# Altium schematic sheet AES-MS-MT3620-SK-G_Dev.SchDoc(AES-MS-MT3620-SK-G_Dev)
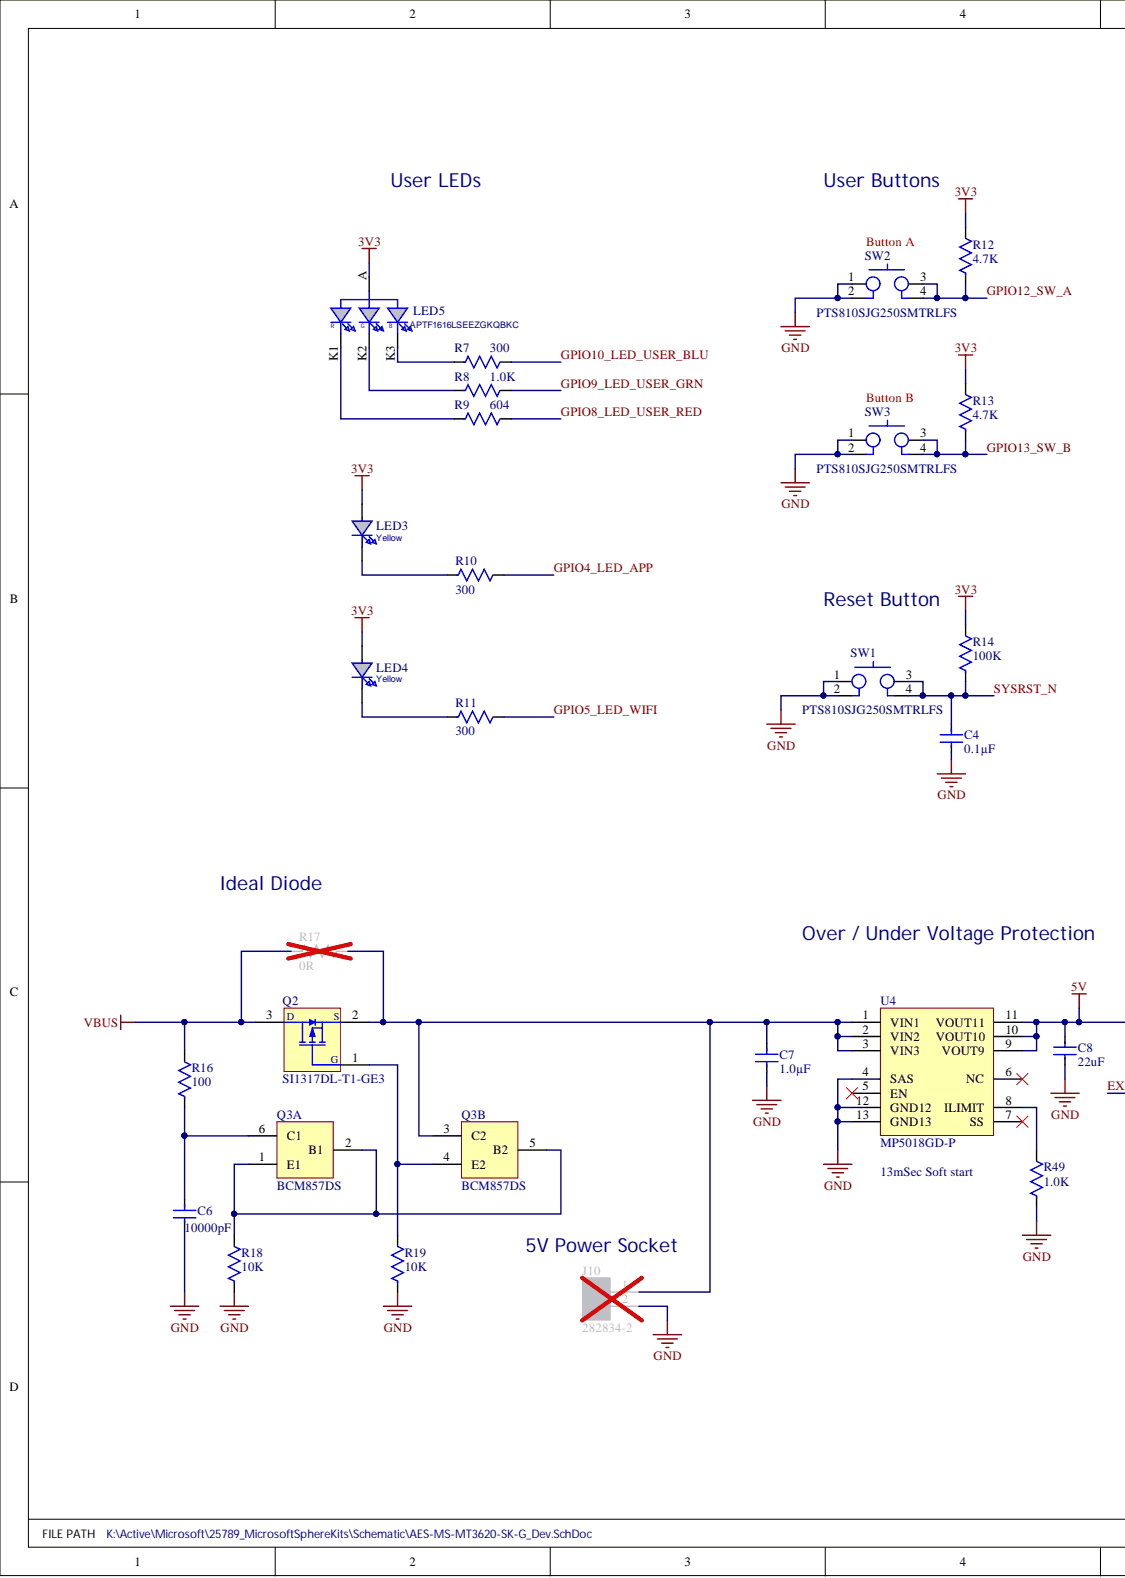
[diagram p0: AES-MS-MT3620-SK-G_Dev.SchDoc, left]
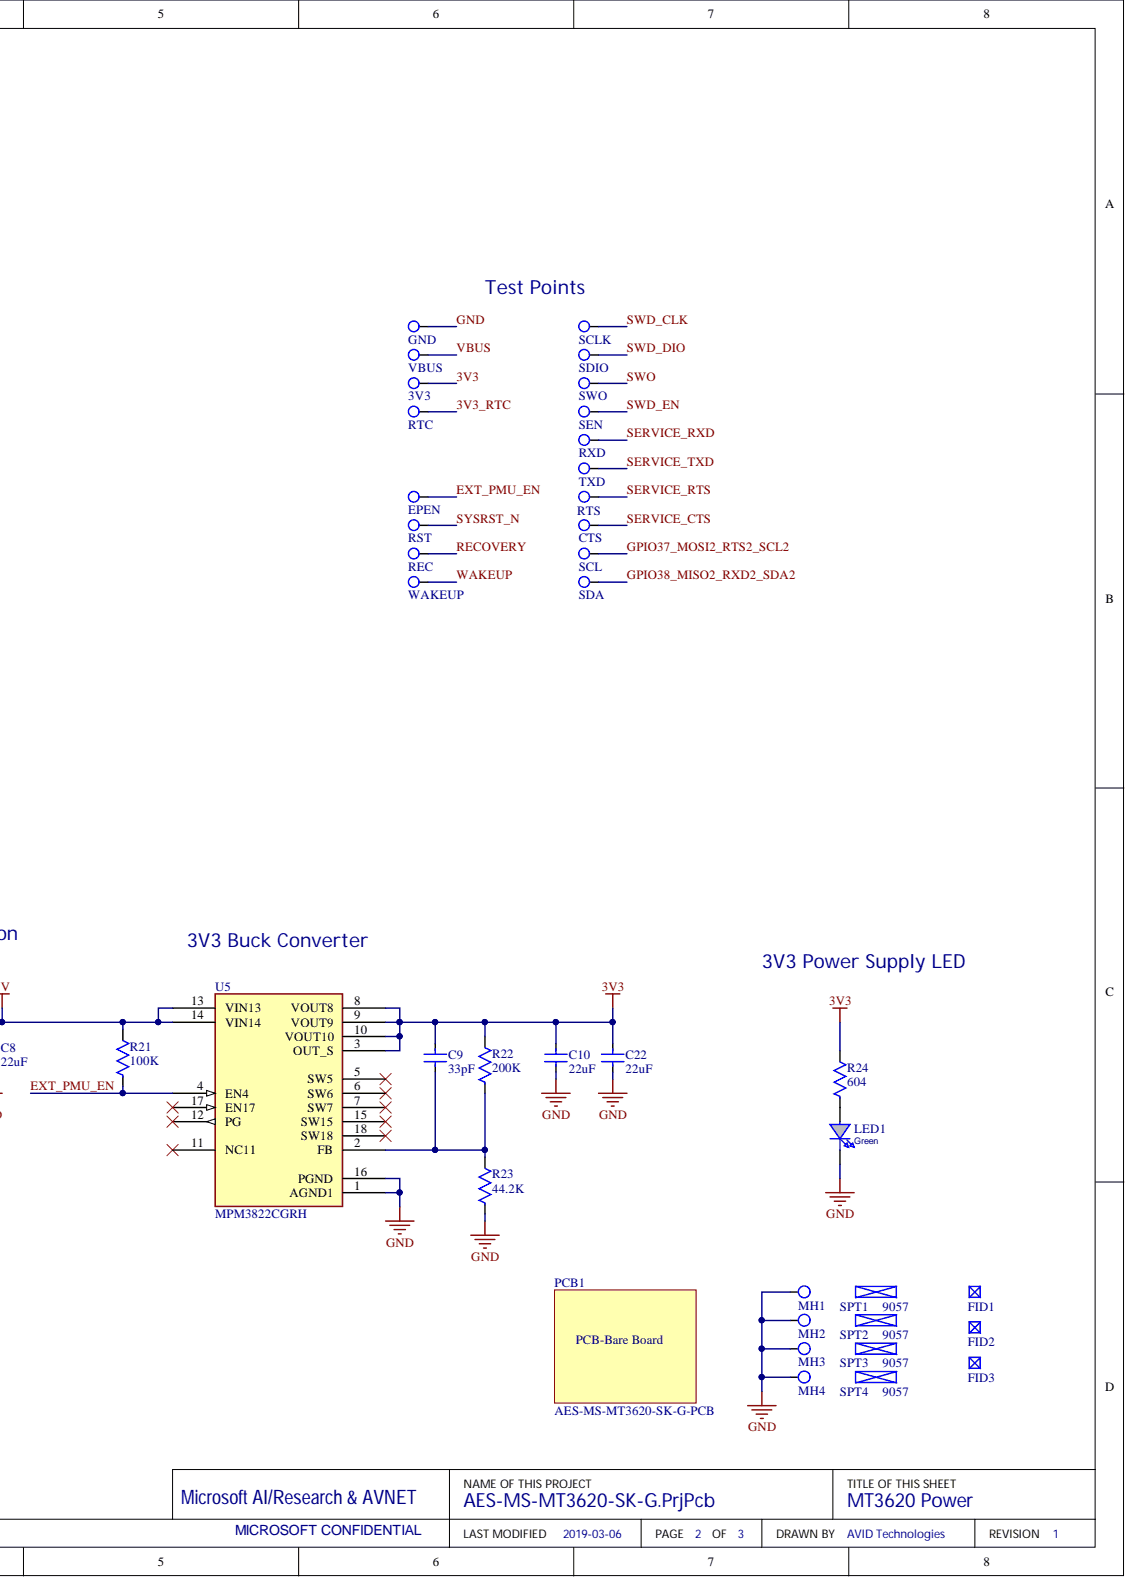
[diagram p1: AES-MS-MT3620-SK-G_Dev.SchDoc, right]

## Parts
3V3  TestPoint  [Pad1.27mm]  (no BOM)
C4  Capacitance: 0.1µF  [CAPC1005-EIA0402 0.55MM MED]  (hidden comment: 0.1uF)
C6  10000pF  [CAPC1005-EIA0402 0.55MM MED]
C7  1.0µF  [CAPC1005-EIA0402 0.55MM MED]
C8  22uF  [TDK_0805]
C9  33pF  [CAPC1005-EIA0402 0.55MM MED]
C10  22uF  [TDK_0805]
C22  22uF  [TDK_0805]
CTS  TestPoint  [Pad1.27mm]  (no BOM)
EPEN  TestPoint  [Pad1.27mm]  (no BOM)
GND  TestPoint  [Pad1.27mm]  (no BOM)
J10  282834-2  [282834-2-TB]
LED1  Green  [LG_L29K-F2J1-24-Z]
LED3  Yellow
LED4  Yellow
LED5  APTF1616LSEEZGKQBKC  [APTF1616]
MH1  Mounting Hole  [MH]  (value not drawn)  (no BOM)
MH2  Mounting Hole  [MH]  (value not drawn)  (no BOM)
MH3  Mounting Hole  [MH]  (value not drawn)  (no BOM)
MH4  Mounting Hole  [MH]  (value not drawn)  (no BOM)
PCB1  AES-MS-MT3620-SK-G-PCB
Q2  SI1317DL-T1-GE3  [VISHAY_SOT-323]
Q3  BCM857DS  [NXP-SOT457]  (parts A,B)
R7  300  [RES 0402 MEDIUM DENSITY]
R8  1.0K  [RES 0402 MEDIUM DENSITY]
R9  604  [RES 0402 MEDIUM DENSITY]
R10  300  [RES 0402 MEDIUM DENSITY]
R11  300  [RES 0402 MEDIUM DENSITY]
R12  4.7K  [RES 0402 MEDIUM DENSITY]
R13  4.7K  [RES 0402 MEDIUM DENSITY]
R14  100K  [RES 0402 MEDIUM DENSITY]
R16  100  [RES 0402 MEDIUM DENSITY]
R17  Resistance (Ohms): 0R  [RES 0402 MEDIUM DENSITY]  (hidden comment: 0.0)
R18  10K  [RES 0402 MEDIUM DENSITY]
R19  10K  [RES 0402 MEDIUM DENSITY]
R21  100K  [RES 0402 MEDIUM DENSITY]
R22  200K  [RES 0402 MEDIUM DENSITY]
R23  44.2K  [RES 0402 MEDIUM DENSITY]
R24  604  [RES 0402 MEDIUM DENSITY]
R49  1.0K  [RES 0402 MEDIUM DENSITY]
REC  TestPoint  [Pad1.27mm]  (no BOM)
RST  TestPoint  [Pad1.27mm]  (no BOM)
RTC  TestPoint  [Pad1.27mm]  (no BOM)
RTS  TestPoint  [Pad1.27mm]  (no BOM)
RXD  TestPoint  [Pad1.27mm]  (no BOM)
SCL  TestPoint  [Pad1.27mm]  (no BOM)
SCLK  TestPoint  [Pad1.27mm]  (no BOM)
SDA  TestPoint  [Pad1.27mm]  (no BOM)
SDIO  TestPoint  [Pad1.27mm]  (no BOM)
SEN  TestPoint  [Pad1.27mm]  (no BOM)
SPT1  Manufacturer Part Number 1: 9057  (hidden comment: SUPPORT 1/4IN)  (mechanical)
SPT2  Manufacturer Part Number 1: 9057  (hidden comment: SUPPORT 1/4IN)  (mechanical)
SPT3  Manufacturer Part Number 1: 9057  (hidden comment: SUPPORT 1/4IN)  (mechanical)
SPT4  Manufacturer Part Number 1: 9057  (hidden comment: SUPPORT 1/4IN)  (mechanical)
SW1  PTS810SJG250SMTRLFS  [PTS810]
SW2  PTS810SJG250SMTRLFS  [PTS810]
SW3  PTS810SJG250SMTRLFS  [PTS810]
SWO  TestPoint  [Pad1.27mm]  (no BOM)
TXD  TestPoint  [Pad1.27mm]  (no BOM)
U4  MP5018GD-P  [MP5018GD_QFN-12]
U5  MPM3822CGRH  [MPM3822C_QFN-18]
VBUS  TestPoint  [Pad1.27mm]  (no BOM)
WAKEUP  TestPoint  [Pad1.27mm]  (no BOM)

## Nets
5V: C8.2 R21.1 U4.9(VOUT9) U4.10(VOUT10) U4.11(VOUT11) U5.13(VIN13) U5.14(VIN14)
3V3: 3V3.1 C9.2 C10.2 C22.2 LED3.A LED4.A LED5.A R12.1 R13.1 R14.1 R22.1 R24.1 U5.3(OUT_S) U5.8(VOUT8) U5.9(VOUT9) U5.10(VOUT10)
3V3_RTC: RTC.1
EXT_PMU_EN: EPEN.1 R21.2 U5.4(EN4)
GND: C4.1 C6.1 C7.1 C8.1 C10.1 C22.1 GND.1 J10.2 LED1.K MH1.1 MH2.1 MH3.1 MH4.1 R18.2 R19.2 R23.2 R49.2 SW1.1 SW1.2 SW2.1 SW2.2 SW3.1 SW3.2 U4.4(SAS) U4.12(GND12) U4.13(GND13) U5.1(AGND1) U5.16(PGND)
GPIO4_LED_APP: R10.1
GPIO5_LED_WIFI: R11.1
GPIO8_LED_USER_RED: R9.1
GPIO9_LED_USER_GRN: R8.1
GPIO10_LED_USER_BLU: R7.1
GPIO12_SW_A: R12.2 SW2.3 SW2.4
GPIO13_SW_B: R13.2 SW3.3 SW3.4
GPIO37_MOSI2_RTS2_SCL2: SCL.1
GPIO38_MISO2_RXD2_SDA2: SDA.1
RECOVERY: REC.1
SERVICE_CTS: CTS.1
SERVICE_RTS: RTS.1
SERVICE_RXD: RXD.1
SERVICE_TXD: TXD.1
SWD_CLK: SCLK.1
SWD_DIO: SDIO.1
SWD_EN: SEN.1
SWO: SWO.1
SYSRST_N: C4.2 R14.2 RST.1 SW1.3 SW1.4
VBUS: Q2.3 R16.1 R17.2 VBUS.1
WAKEUP: WAKEUP.1
NetC6_2: C6.2 Q3.6(C1) R16.2
NetC7_2: C7.2 J10.1 Q2.2 Q3.3(C2) R17.1 U4.1(VIN1) U4.2(VIN2) U4.3(VIN3)
NetC9_1: C9.1 R22.2 R23.1 U5.2(FB)
NetLED1_A: LED1.A R24.2
NetLED3_K: LED3.K R10.2
NetLED4_K: LED4.K R11.2
NetLED5_K1: LED5.K1 R9.2
NetLED5_K2: LED5.K2 R8.2
NetLED5_K3: LED5.K3 R7.2
NetQ2_1: Q2.1 Q3.4(E2) R19.1
NetQ3_1: Q3.1(E1) Q3.2(B1) Q3.5(B2) R18.1
NetR49_1: R49.1 U4.8(ILIMIT)

Not connected: U4 5(EN) 6(NC) 7(SS); U5 5(SW5) 6(SW6) 7(SW7) 11(NC11) 12(PG) 15(SW15) 17(EN17) 18(SW18)

## Library data of the parts
3V3 CTS EPEN GND REC RST RTC RTS RXD SCL SCLK SDA SDIO SEN SWO TXD VBUS WAKEUP = TestPoint: Test Point; Library Name: generic.SchLib
C4 = 0.1µF 0402 X7R 50V: CAP CER 0.1UF 25V 10% X5R 0402; Manufacturer Part Number 1: CL05A104KA5NNNC; Manufacturer 1: Samsung; Width: 0.02inch; Voltage Rating (DC): 25V; Voltage Rating: 25V; Voltage: 25V; Tolerance: 10%; Thickness: 0.022inch; Supplier Part Number 1: 1276-1043-1-ND; Supplier 1: Digi-Key; Stock 1: 100000; Radiation Hardening: No; Pricing 1: 1=0.1, 10=0.032, 100=0.0141, 500=0.0101, 1000=0.0079, 2500=0.0072, 5000=0.0066 (USD); Packaging: Tape and Reel; Package Quantity: 1; Mount: Surface Mount; Min Operating Temperature: -55°C; Max Operating Temperature: 85°C; Length: 1mm; Lead Free: Lead Free; Height: 0.5mm; Dielectric: X5R; Depth: 0.5mm; Component Kind: Standard; Case Code (Metric): 1005; Case Code (Imperial): 0402; Case/Package: 0402; Library Name: Sphere.SCHLIB
C6 = 10000pF 0402 X7R 50V: CAP CER 10000PF 50V 10% X7R 0402; Manufacturer Part Number 1: C1005X7R1H103K050BB; Manufacturer 1: TDK; Voltage - Rated: 50V; Tolerance: ±10%; Thickness (Max): 0.022" (0.55mm); Temperature Coefficient: X7R; Supplier Part Number 1: 445-6850-2-ND; Supplier 1: Digi-Key; Stock 1: 47833; Size / Dimension: 0.039" L x 0.020" W (1.00mm x 0.50mm); RoHS: RoHS Compliant; Ratings: -; Pricing 1: 0.00685; Packaging: Tape & Reel (TR); Package / Case: 0402 (1005 Metric); Operating Temperature: -55°C ~ 125°C; Mounting Type: Surface Mount, MLCC; Lead Style: -; Lead Spacing: -; Height - Seated (Max): -; Features: -; Component Kind: Standard; Category: Capacitors; Capacitance: 10000pF; Applications: General Purpose; Library Name: Sphere.SCHLIB
C7 = 1.0µF 0402 X5R 35V: CAP CER 1UF 35V 10% X5R 0402; Manufacturer Part Number 1: C1005X5R1V105K050BC; Manufacturer 1: TDK Corporation; Voltage - Rated: 35V; Tolerance: ±10%; Thickness (Max): 0.022" (0.55mm); Temperature Coefficient: X5R; Supplier Part Number 1: 445-9073-2-ND; Supplier 1: Digi-Key; Stock 1: 47833; Size / Dimension: 0.039" L x 0.020" W (1.00mm x 0.50mm); RoHS: RoHS Compliant; Ratings: -; Pricing 1: 0.0186; Packaging: Tape & Reel (TR); Package / Case: 0402 (1005 Metric); Operating Temperature: -55°C ~ 125°C; Mounting Type: Surface Mount, MLCC; Lead Style: -; Lead Spacing: -; Height - Seated (Max): -; Features: -; Component Kind: Standard; Category: Capacitors; Capacitance: 1.0µF; Applications: General Purpose; Library Name: Sphere.SCHLIB
C8 C10 C22 = 22uF 0805 X5R 16V: CAP CER 22UF 16V X5R 0805; Manufacturer Part Number 1: CL21A226MOCLRNC; Manufacturer 1: Samsung; Tolerance: 20%; Width: 0.049inch; Voltage Rating: 16V; Thickness: 0.037inch; Supplier Part Number 1: 1276-6780-1-ND; Supplier 1: Digi-Key; Stock 1: 40000; Pricing 1: 1=0.36, 10=0.246, 100=0.1397, 500=0.0986, 1000=0.0863 (USD); Packaging: Tape and Reel; Mount: Surface Mount; Min Operating Temperature: -55°C; Max Operating Temperature: 85°C; Length: 0.079inch; Component Kind: Standard; Case Code (Metric): 2012; Case Code (Imperial): 0805; Case/Package: 0805; Capacitance: 22uF; Library Name: Sphere.SCHLIB
C9 = 33pF 0402 C0G, NP0 50V: CAP CER 33PF 50V 5% NP0 0402; Manufacturer Part Number 1: C1005C0G1H330J050BA; Manufacturer 1: TDK; Voltage - Rated: 50V; Tolerance: ±5%; Thickness (Max): 0.022" (0.55mm); Temperature Coefficient: C0G, NP0; Supplier Part Number 1: 445-1241-2-ND; Supplier 1: Digi-Key; Stock 1: 47833; Size / Dimension: 0.039" L x 0.020" W (1.00mm x 0.50mm); RoHS: RoHS Compliant; Ratings: -; Pricing 1: 0.00274; Packaging: Tape & Reel (TR); Package / Case: 0402 (1005 Metric); Operating Temperature: -55°C ~ 125°C; Mounting Type: Surface Mount, MLCC; Lead Style: -; Lead Spacing: -; Height - Seated (Max): -; Features: -; Component Kind: Standard; Category: Capacitors; Capacitance: 33pF; Applications: General Purpose; Library Name: Sphere.SCHLIB
J10 = CONN 2 POS TB 282834-2: TERM BLOCK 2POS SIDE ENT 2.54MM; Manufacturer 1: TE Connectivity; Wire Gauge (Min): 30AWG; Voltage Rating: 150V; Thread Size: M1.6; Tail Length: 3.4mm; Side Stackable: Yes (With); Screw Flange: No (Without); Plating: Brass,Steel; Number of Positions Per Level: 2; Number of Levels: 1; Max Current Rating: 10A; Gender: Male; Connector Type: Receptacle; Approvals: UL; Wire Gauge (Max): 30AWG; Wire/Cable Gauge: 30AWG; Width: 6.5mm; Voltage: 150V; Termination: Solder; Supplier Part Number 1: A98333-ND; Supplier 1: Digi-Key; Stock 1: 3162; Screwless Terminal Block: Yes (With); REACH SVHC: Unknown; Radiation Hardening: No; Pricing 1: 1=0.88, 10=0.62, 25=0.5784, 50=0.525, 100=0.5228, 250=0.4414, 500=0.4182, 1000=0.3718, 5000=0.3485 (USD); Pitch: 2.54mm; Packaging: Bulk; Package Quantity: 300; Orientation: Straight; Number of Rows: 1; Number of Positions: 2; Number of Poles: 2; Number of Contacts: 2; Number of Circuits: 2; Mount: Through Hole; Min Operating Temperature: -40°C; Max Voltage Rating (AC): 150V; Max Supply Voltage (AC): 150V; Max Operating Temperature: 105°C; Material: Nylon; Manufacturer Part Number 1: 282834-2; Length: 5.54mm; Lead Free: Lead Free; Interlock: No (Without); Housing Material: Polyamide; Housing Colour: Green; Flammability Rating: UL94 V-0; ELV: Compliant; Depth: 6.5mm; Current Rating: 10A; Current: 10A; Contact Plating: Tin; Contact Material: Brass; Component Kind: Standard; Colour: Green; Closed End: No (Without); Library Name: Sphere.SCHLIB
LED1 = LED_1608_GREEN_LTST: LED GREEN 35MCD 0603 SMD; Manufacturer Part Number 1: LTST-C191KGKT; Manufacturer 1: Vishay Lite-On; Wavelength: 574nm; Viewing Angle: 130°; Test Current: 20mA; Reverse Voltage: 5V; Power Rating: 75mW; Number of LEDs: 1; Lens Transparency: Clear; Lens Style: Clear,Colorless,Diffused,Rectangular; Lens Colour: Clear,Colorless; Forward Voltage: 2V; Forward Current: 30mA; Dominant Wavelength: 571nm; Width: 0.8mm; Supplier Part Number 1: 160-1446-1-ND; Supplier 1: Digi-Key; Stock 1: 2051115; Radiation Hardening: No; Pricing 1: 1=0.29, 10=0.215, 25=0.16, 100=0.1153, 250=0.0798, 500=0.0709, 1000=0.0564, 2500=0.0553 (USD); Power Dissipation: 75mW; Packaging: Tape and Reel; Package Quantity: 1; Number of Pins: 2; Number of Elements: 1; Mount: Surface Mount; Min Operating Temperature: -55°C; Max Operating Temperature: 85°C; Luminous Intensity: 35mcd; Length: 1.6mm; Lead Free: Lead Free; Illumination Colour: Green; Height: 0.55mm; Depth: 0.8mm; Component Kind: Standard; Colour: Green; Case Code (Metric): 1608; Case Code (Imperial): 0603; Case/Package: 0603; Library Name: Sphere.SCHLIB
LED3 LED4 = LED_1608_YELLOW_LTST: LED YELLOW CLEAR 26MCD CHIP SMD; Manufacturer Part Number 1: LTST-C193KSKT-5A; Manufacturer 1: Vishay Lite-On; Viewing Angle: 130°; Test Current: 5mA; Reverse Voltage: 5V; Power Dissipation: 75mW; Number of LEDs: 1; Lens Style: Colorless,Diffused,Rectangular; Lens Colour: Clear,Colorless; Forward Voltage: 2.3V; Forward Current: 30mA; Dominant Wavelength: 594.5nm; Width: 0.8mm; Supplier Part Number 1: 160-1831-1-ND; Supplier 1: Digi-Key; Stock 1: 120962; Radiation Hardening: No; Pricing 1: 1=0.41, 10=0.296, 25=0.2268, 100=0.148, 250=0.1085, 500=0.0921, 1000=0.0757, 2500=0.0658 (USD); Packaging: Tape and Reel; Package Quantity: 1; Number of Pins: 2; Number of Elements: 1; Mount: Surface Mount; Min Operating Temperature: -55°C; Max Operating Temperature: 85°C; Luminous Intensity: 26mcd; Lens Transparency: Clear; Length: 1.6mm; Lead Free: Lead Free; Illumination Colour: Yellow; Height: 0.35mm; Depth: 0.8mm; Component Kind: Standard; Colour: Yellow; Case Code (Metric): 1608; Case Code (Imperial): 0603; Case/Package: 0603; Library Name: Sphere.SCHLIB
LED5 = LED_RGB: LED RGB 621NM 525NM 465NM 0606; Manufacturer 1: Kingbright; Viewing Angle: 120°; Test Current: 2mA; Luminous Intensity: 14mcd; Lens Transparency: Clear; Lens Colour: Colorless; Forward Voltage: 2.7V; Colour: Blue,Green,Red; Width: 1.6mm; Supplier Part Number 1: 754-1972-1-ND; Supplier 1: Digi-Key; Stock 1: 80914; Pricing 1: 1=1.01, 10=0.824, 25=0.6336, 100=0.5704, 250=0.507, 500=0.431, 1000=0.3549 (USD); Packaging: Tape and Reel; Mount: Surface Mount; Manufacturer Part Number 1: APTF1616LSEEZGKQBKC; Length: 1.6mm; Height: 0.9mm; Dominant Wavelength: 465nm; Component Kind: Standard; Case Code (Metric): 1616; Case Code (Imperial): 0606; Case/Package: 0606; Library Name: Sphere.SCHLIB
PCB1 = AES-MS-MT3620-SK-G: PCB - FR4-High Tem, 170C Tg, 4 Layer, ENIG, 1.6mm, RoHS; Component Kind: Standard; Library Name: Sphere.SCHLIB
Q2 = FET P SI1317DL-T1-GE3: MOSFET P-CH 20V 1.4A SC70; Manufacturer 1: Vishay Siliconix; Turn-On Delay Time: 12ns; Turn-Off Delay Time: 23ns; Threshold Voltage: 450mV; Rise Time: 30ns; Rds On Max: 150mR; Power Dissipation: 400mW; Number of Elements: 1; Max Power Dissipation: 500mW; Input Capacitance: 272pF; Gate to Source Voltage (Vgs): 8V; Fall Time: 18ns; Element Configuration: Single; Drain to Source Resistance: 270mR; Weight: 0.004395oz; Supplier Part Number 1: SI1317DL-T1-GE3CT-ND; Supplier 1: Digi-Key; Stock 1: 93000; REACH SVHC: No SVHC; Radiation Hardening: No; Pricing 1: 1=0.58, 10=0.453, 100=0.3109, 500=0.2132, 1000=0.1599 (USD); Packaging: Tape and Reel; Package Quantity: 3000; Number of Pins: 3; Number of Channels: 1; Mount: Surface Mount; Min Operating Temperature: -50°C; Max Operating Temperature: 150°C; Manufacturer Part Number 1: SI1317DL-T1-GE3; Lead Free: Lead Free; Drain to Source Voltage (Vdss): 20V; Drain to Source Breakdown Voltage: 20V; Continuous Drain Current (ID): 1.4A; Contact Plating: Matte,Tin; Component Kind: Standard; Case/Package: SOT-323; Library Name: Sphere.SCHLIB
Q3 = BCM857DS,115: BJT ARRAY, DUAL PNP, -45V, -100MA, 6-SOT-457; Manufacturer 1: NXP; Zener Voltage: 3.3V; Transition Frequency: 175MHz; Tolerance: 5%; Test Current: 5mA; Power Dissipation: 380mW; Number of Elements: 2; Max Collector Current: 100mA; Max Breakdown Voltage: 45V; Emitter Base Voltage (VEBO): 5V; Collector Base Voltage (VCBO): 50V; Width: 0.25inch; Weight: 0.01lb; Supplier Part Number 1: 1727-4884-1-ND; Supplier 1: Digi-Key; Stock 1: 369; REACH SVHC: No SVHC; Radiation Hardening: No; Pricing 1: 1=0.46, 10=0.343, 100=0.2137, 500=0.1462, 1000=0.1125 (USD); Polarity: PNP; Packaging: Tape and Reel; Package Quantity: 1; Number of Pins: 6; Mount: Surface Mount; Min Operating Temperature: -65°C; Max Power Dissipation: 380mW; Max Operating Temperature: 150°C; Manufacturer Part Number 1: BCM857DS,115; Length: 0.25inch; Lead Free: Lead Free; Height: 0.25inch; Gain Bandwidth Product: 175MHz; Frequency: 175MHz; Element Configuration: Dual; Contact Plating: Tin; Component Kind: Standard; Collector Emitter Voltage (VCEO): 45V; Collector Emitter Breakdown Voltage: 45V; Case/Package: SOT-457; Library Name: Sphere.SCHLIB
R7 R10 R11 = 300 0402: RES 300 OHM 1/10W 1% 0402 SMD; Manufacturer Part Number 1: ERJ-2RKF3000X; Manufacturer 1: Panasonic; Temperature Coefficient: ±100ppm/°C; Power (Watts): 0.1W, 1/10W; Tolerance: ±1%; Supplier Part Number 2: 2302577; Supplier Part Number 1: P300LCT-ND; Supplier Device Package: 0402; Supplier 2: Farnell; Supplier 1: Digi-Key; Stock 1: 55335; Size / Dimension: 0.039" L x 0.020" W (1.00mm x 0.50mm); RoHS: RoHS Compliant; Resistance (Ohms): 300; Pricing 1: 1=0.07, 50=0.0128, 100=0.0097, 250=0.0072, 500=0.00532, 1000=0.00355, 5000=0.0025 (GBP); Packaging: Cut Tape (CT); Package / Case: 0402 (1005 Metric); Number of Terminations: 2; Height: 0.016" (0.40mm); Composition: Thick Film; Component Kind: Standard; Category: Resistors; Library Name: Sphere.SCHLIB
R8 R49 = 1.0K 0402: RES 1.0K OHM 1/10W 1% 0402 SMD; Manufacturer Part Number 1: ERJ-2RKF1001X; Manufacturer 1: Panasonic; Working Voltage: 50V; Temperature Coefficient: 100ppm/°C; Power Rating: 100mW; Width: 0.5mm; Voltage Rating (DC): 50V; Voltage Rating: 50V; Tolerance: 1%; Termination: SMD/SMT; Supplier Part Number 1: P1.00KLCT-ND; Supplier 1: Digi-Key; Stock 1: 16869599; Resistance (Ohms): 1.0K; REACH SVHC: No SVHC; Radiation Hardening: No; Pricing 1: 1=0.1, 10=0.045, 100=0.0184, 1000=0.0083, 2500=0.0072, 5000=0.0059 (USD); Packaging: Tape and Reel; Package Quantity: 1; Number of Terminations: 2; Number of Pins: 2; Mount: Surface Mount; Min Operating Temperature: -55°C; Military Standard: Not; Max Power Dissipation: 100mW; Max Operating Temperature: 155°C; Length: 1mm; Lead Free: Lead Free; Height: 0.35mm; Features: Automotive AEC-Q200; Depth: 0.5mm; Contact Plating: Tin; Composition: Thick Film; Component Kind: Standard; Case Code (Metric): 1005; Case Code (Imperial): 0402; Case/Package: 0402; Library Name: Sphere.SCHLIB
R9 R24 = 604 0402: RES 604 OHM 1/10W 1% 0402 SMD; Manufacturer Part Number 1: ERJ-2RKF6040X; Manufacturer 1: Panasonic; Temperature Coefficient: ±100ppm/°C; Power (Watts): 0.1W, 1/10W; Tolerance: ±1%; Supplier Part Number 1: P604LCT-ND; Supplier Device Package: 0402; Supplier 1: Digi-Key; Stock 1: 86930; Size / Dimension: 0.039" L x 0.020" W (1.00mm x 0.50mm); RoHS: RoHS Compliant; Resistance (Ohms): 604; Pricing 1: 1=0.07, 50=0.0128, 100=0.0097, 250=0.0072, 500=0.00532, 1000=0.00355, 5000=0.0025 (GBP); Packaging: Cut Tape (CT); Package / Case: 0402 (1005 Metric); Number of Terminations: 2; Height: 0.016" (0.40mm); Features: -; Composition: Thick Film; Component Kind: Standard; Category: Resistors; Library Name: Sphere.SCHLIB
R12 R13 = 4.7K 0402: RES 4.7K OHM 1/10W 1% 0402 SMD; Manufacturer Part Number 1: ERJ-2RKF4701X; Manufacturer 1: Panasonic; Temperature Coefficient: ±100ppm/°C; Power (Watts): 0.1W, 1/10W; Tolerance: ±1%; Supplier Part Number 1: P4.70KLCT-ND; Supplier Device Package: 0402; Supplier 1: Digi-Key; Stock 1: 1251398; Size / Dimension: 0.039" L x 0.020" W (1.00mm x 0.50mm); RoHS: RoHS Compliant; Resistance (Ohms): 4.7K; Pricing 1: 1=0.07, 50=0.0128, 100=0.0097, 250=0.0072, 500=0.00532, 1000=0.00355, 5000=0.0025 (GBP); Packaging: Cut Tape (CT); Package / Case: 0402 (1005 Metric); Number of Terminations: 2; Height: 0.016" (0.40mm); Features: -; Composition: Thick Film; Component Kind: Standard; Category: Resistors; Library Name: Sphere.SCHLIB
R14 R21 = 100K 0402: RES 100K OHM 1/10W 1% 0402 SMD; Manufacturer Part Number 1: ERJ-2RKF1003X; Manufacturer 1: Panasonic; Temperature Coefficient: ±100ppm/°C; Power (Watts): 0.1W, 1/10W; Tolerance: ±1%; Supplier Part Number 1: P100KLCT-ND; Supplier Device Package: 0402; Supplier 1: Digi-Key; Stock 1: 2310913; Size / Dimension: 0.039" L x 0.020" W (1.00mm x 0.50mm); RoHS: RoHS Compliant; Resistance (Ohms): 100K; Pricing 1: 1=0.07, 50=0.0128, 100=0.0097, 250=0.0072, 500=0.00532, 1000=0.00355, 5000=0.0025 (GBP); Packaging: Cut Tape (CT); Package / Case: 0402 (1005 Metric); Number of Terminations: 2; Height: 0.016" (0.40mm); Features: -; Composition: Thick Film; Component Kind: Standard; Category: Resistors; Library Name: Sphere.SCHLIB
R16 = 100 0402: RES 100 OHM 1/10W 1% 0402 SMD; Manufacturer Part Number 1: ERJ-2RKF1000X; Manufacturer 1: Panasonic; Temperature Coefficient: ±100ppm/°C; Power (Watts): 0.1W, 1/10W; Tolerance: ±1%; Supplier Part Number 1: P100LCT-ND; Supplier Device Package: 0402; Supplier 1: Digi-Key; Stock 1: 3662159; Size / Dimension: 0.039" L x 0.020" W (1.00mm x 0.50mm); RoHS: RoHS Compliant; Resistance (Ohms): 100; Pricing 1: 1=0.07, 50=0.0128, 100=0.0097, 250=0.0072, 500=0.00532, 1000=0.00355, 5000=0.0025 (GBP); Packaging: Cut Tape (CT); Package / Case: 0402 (1005 Metric); Number of Terminations: 2; Height: 0.016" (0.40mm); Composition: Thick Film; Component Kind: Standard; Category: Resistors; Library Name: Sphere.SCHLIB
R17 = 0R 0402: RES 0.0 OHM 1/10W JUMP 0402 SMD; Manufacturer Part Number 1: ERJ-2GE0R00X; Manufacturer 1: Panasonic; Tolerance: Jumper; Power (Watts): 0.1W, 1/10W; Temperature Coefficient: -; Supplier Part Number 1: P0.0JCT-ND; Supplier Device Package: 0402; Supplier 1: Digi-Key; Stock 1: 8025371; Size / Dimension: 0.039" L x 0.020" W (1.00mm x 0.50mm); RoHS: RoHS Compliant; Pricing 1: 1=0.07, 50=0.009, 100=0.0069, 250=0.00508, 500=0.00376, 1000=0.00251, 5000=0.00176 (GBP); Packaging: Cut Tape (CT); Package / Case: 0402 (1005 Metric); Number of Terminations: 2; Height: 0.016" (0.40mm); Features: -; Composition: Thick Film; ComponentLink1URL: http://industrial.panasonic.com/www-cgi/jvcr13pz.cgi?E+PZ+3+AOA0001+ERJ2GE0R00X+7+WW; ComponentLink1Description: http://industrial.panasonic.com/www-cgi/jvcr13pz.cgi?E+PZ+3+AOA0001+ERJ2GE0R00X+7+WW; Component Kind: Standard; Category: Resistors; Library Name: Sphere.SCHLIB
R18 R19 = 10K 0402: RES 10K OHM 1/10W 1% 0402 SMD; Manufacturer Part Number 1: ERJ-2RKF1002X; Manufacturer 1: Panasonic; Temperature Coefficient: ±100ppm/°C; Power (Watts): 0.1W, 1/10W; Tolerance: ±1%; Supplier Part Number 1: P10.0KLCT-ND; Supplier Device Package: 0402; Supplier 1: Digi-Key; Stock 1: 4805447; Size / Dimension: 0.039" L x 0.020" W (1.00mm x 0.50mm); RoHS: RoHS Compliant; Resistance (Ohms): 10K; Pricing 1: 1=0.07, 50=0.0128, 100=0.0097, 250=0.0072, 500=0.00532, 1000=0.00355, 5000=0.0025 (GBP); Packaging: Cut Tape (CT); Package / Case: 0402 (1005 Metric); Number of Terminations: 2; Height: 0.016" (0.40mm); Features: -; Composition: Thick Film; Component Kind: Standard; Category: Resistors; Library Name: Sphere.SCHLIB
R22 = 200K 0402: RES 200K OHM 1/10W 1% 0402 SMD; Manufacturer Part Number 1: ERJ-2RKF2003X; Manufacturer 1: Panasonic; Voltage Rating (DC): 50V; Temperature Coefficient: 100ppm/°C; Power Rating: 100mW; Width: 0.5mm; Voltage Rating: 50V; Tolerance: 1%; Termination: SMD/SMT; Supplier Part Number 1: P200KLCT-ND; Supplier 1: Digi-Key; Stock 1: 1951910; Resistance (Ohms): 200K; REACH SVHC: No SVHC; Radiation Hardening: No; Pricing 1: 1=0.1, 10=0.045, 100=0.0184, 1000=0.0083, 2500=0.0072, 5000=0.0059 (USD); Packaging: Cut Tape; Package Quantity: 1; Number of Terminations: 2; Mount: Surface Mount; Min Operating Temperature: -55°C; Military Standard: Not; Max Power Dissipation: 100mW; Max Operating Temperature: 155°C; Length: 1mm; Lead Free: Lead Free; Height: 0.35mm; Features: Automotive AEC-Q200; Depth: 0.5mm; Contact Plating: Tin; Composition: Thick Film; Component Kind: Standard; Case Code (Metric): 1005; Case Code (Imperial): 0402; Case/Package: 0402; Library Name: Sphere.SCHLIB
R23 = 44.2K 0402: RES 44.2K OHM 1/10W 1% 0402 SMD; Manufacturer Part Number 1: ERJ-2RKF4422X; Manufacturer 1: Panasonic; Voltage Rating (DC): 50V; Temperature Coefficient: 100ppm/°C; Power Rating: 100mW; Width: 0.02inch; Voltage Rating: 50V; Tolerance: 1%; Termination: SMD/SMT; Supplier Part Number 1: P44.2KLCT-ND; Supplier 1: Digi-Key; Stock 1: 873516; Resistance (Ohms): 44.2K; REACH SVHC: No SVHC; Pricing 1: 1=0.1, 10=0.045, 100=0.0183, 1000=0.0082, 2500=0.0072, 5000=0.0059 (USD); Packaging: Tape and Reel; Package Quantity: 1; Number of Terminations: 2; Mount: Surface Mount; Min Operating Temperature: -55°C; Military Standard: Not; Max Power Dissipation: 100mW; Max Operating Temperature: 155°C; Length: 1mm; Lead Free: Lead Free; Height: 0.35mm; Features: Automotive AEC-Q200; Depth: 0.5mm; Contact Plating: Tin; Composition: Thick Film; Component Kind: Standard; Case Code (Metric): 1005; Case Code (Imperial): 0402; Case/Package: 0402; Library Name: Sphere.SCHLIB
SPT1-SPT4 = SUPPORT 1/4IN: Slim-Line Lock-In Nylon PCB Support; Manufacturer 1: Keystone Electronics; Outside Diameter: 4.34mm; Mounting Hole Diameter: 0.1inch; Board Thickness: 1.57mm; Supplier Part Number 1: 36-9057-ND; Supplier 1: Digi-Key; Stock 1: 9582; Pricing 1: 1=0.34, 10=0.325, 50=0.2368, 250=0.2044, 1000=0.1632, 2500=0.1486, 5000=0.1394 (USD); Package Quantity: 100; Mount: Snap in; Material: Nylon; Length: 0.4inch; Lead Free: Lead Free; Hole Diameter: 0.1inch; Library Name: Sphere.SCHLIB
SW1-SW3 = SWI TACT PTS810SJG250SMTRLFS: SWITCH TACTILE SPST-NO 0.05A 16V; Manufacturer 1: ITT C&K; Throw Configuration: SPST; Switch Travel: 0.2mm; Switch Function: Off-Mom; Operating Force: 400gf; Mechanical Life: 100000Cycles; Max Current Rating: 50mA; Illumination Type: Non-Illuminated; Actuator Type: Standard; Voltage Rating (DC): 16V; Termination: J-Bend; Supplier Part Number 1: CKN10504CT-ND; Supplier 1: Digi-Key; Stock 1: 24561; Pricing 1: 1=0.32, 10=0.31, 25=0.2988, 50=0.2876, 100=0.2766, 250=0.2545, 500=0.2213, 1000=0.1881 (USD); Packaging: Tape and Reel; Package Quantity: 2900; Orientation: Straight; Mount: Surface Mount; Min Operating Temperature: -40°C; Max Operating Temperature: 85°C; Manufacturer Part Number 1: PTS810SJG250SMTRLFS; Length: 3.2mm; Lead Free: Lead Free; Depth: 4.6mm; Current Rating: 50mA; Contact Plating: Silver; Component Kind: Standard; Colour: Blue; Case/Package: SMD/SMT; Library Name: Sphere.SCHLIB
U4 = IC_MP5018: IC MP5018GD-P CTLR OVP 5V QFN-12; Manufacturer 1: Monolithic Power Systems; Number of Outputs: 1; Max Output Current: 5A; Interface: On/Off; Fault Protection: Over Temperature,Over Voltage,Reverse Current; Supplier Part Number 1: 1589-1191-2-ND; Supplier 1: Digi-Key; Stock 1: 0; Packaging: Tape and Reel; Manufacturer Part Number 1: MP5018GD-P; Component Kind: Standard; Case/Package: QFN; Library Name: Sphere.SCHLIB
U5 = IC_MPM3822CGRH: ULTRA SMALL STEP DOWN MODULE 6V 2A QFN-18; Manufacturer 1: Monolithic Power Systems; Switching Frequency: 1.2MHz; Operating Supply Current: 40uA; Number of Outputs: 1; Min Input Voltage: 2.7V; Max Power Dissipation: 2.8W; Width: 0.12inch; Weight: 0.004339oz; Voltage - Output 1: 6V; Supplier 1: Digi-Key; Stock 1: 12762; Radiation Hardening: No; Pricing 1: 1=3.43, 10=3.064, 25=2.758, 100=2.5127, 250=2.2676 (USD); Packaging: Tape and Reel; Package Quantity: 500; Output Voltage: 6V; Output Current: 2A; Operating Supply Voltage: 6V; Number of Pins: 20; Mount: Surface Mount; Min Operating Temperature: -40°C; Max Output Current: 2A; Max Operating Temperature: 125°C; Max Input Voltage: 6V; Manufacturer Part Number 1: MPM3822CGRH; Length: 0.2inch; Lead Free: Lead Free; Height: 0.06inch; Features: OCP,OTP,UVLO; Component Kind: Standard; Case/Package: QFN-18; Library Name: Sphere.SCHLIB
MH1-MH4 = Mounting Hole: Value: MountingHole; Library Name: Sphere.SCHLIB
